# Altium schematic sheet Power.SchDoc
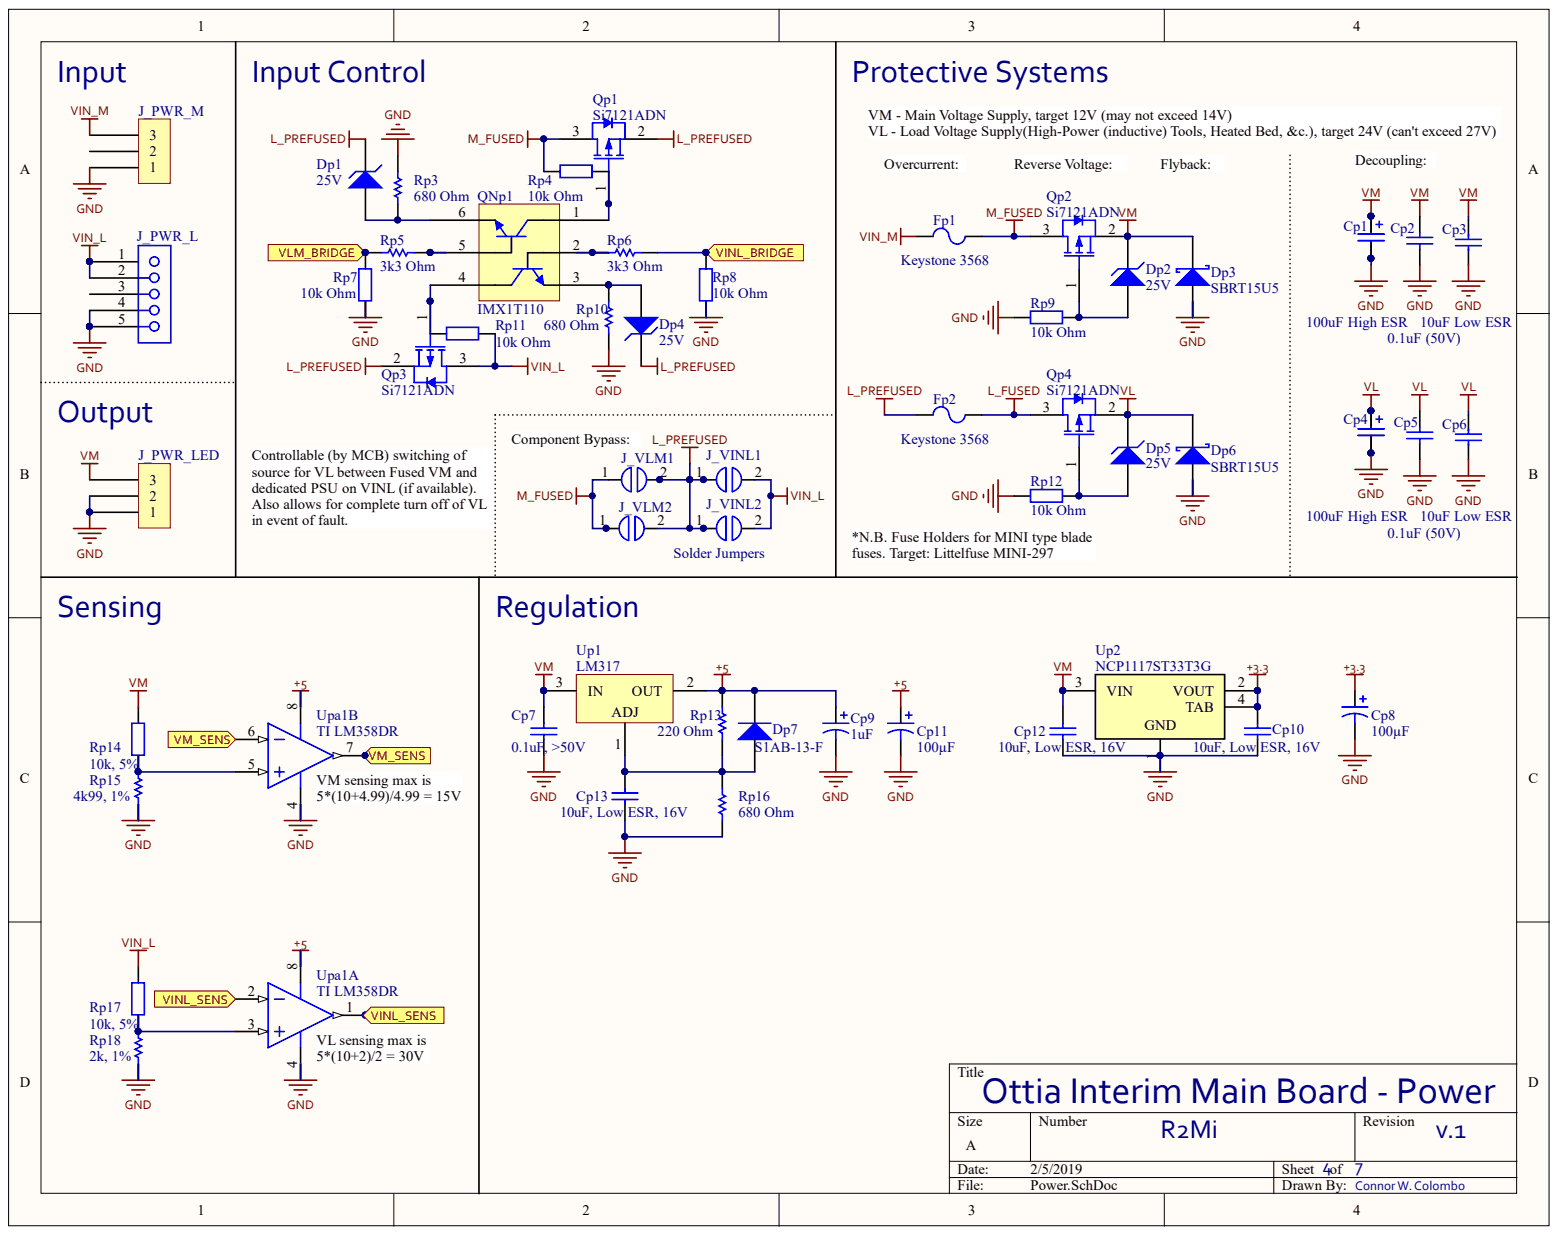
[diagram p0: Power.SchDoc]

## Parts
Cp1  100uF High ESR  [PCB-89xrrqtk3pzsh8e0jed3-1]
Cp2  0.1uF (50V)  [RLP133A]  (value not drawn)
Cp3  10uF Low ESR  [PCB-2281klue1gubltmsqu2t-1]
Cp4  100uF High ESR  [PCB-89xrrqtk3pzsh8e0jed3-1]
Cp5  0.1uF (50V)  [RLP133A]  (value not drawn)
Cp6  10uF Low ESR  [PCB-2281klue1gubltmsqu2t-1]
Cp7  0.1uF, >50V  [CAPC3225X230X50LL20T25]
Cp8  100µF  [PCB-8drnad0ct2lcpyfqi7nf-1]
Cp9  1uF  [PCB-rufcymyklsjsmb7phwvw-1]
Cp10  10uF, Low ESR, 16V  [CAPC3225X170X50NL20T25]
Cp11  100µF  [PCB-8drnad0ct2lcpyfqi7nf-1]
Cp12  10uF, Low ESR, 16V  [CAPC3225X170X50NL20T25]
Cp13  10uF, Low ESR, 16V  [CAPC3225X170X50NL20T25]
Dp1  25V  [PCB-twzvfyfoe7l7t2fej16y-1]
Dp2  25V  [PCB-twzvfyfoe7l7t2fej16y-1]
Dp3  SBRT15U5  [PCB-3b27tvws7ota4tfhp8k4-1]
Dp4  25V  [PCB-twzvfyfoe7l7t2fej16y-1]
Dp5  25V  [PCB-twzvfyfoe7l7t2fej16y-1]
Dp6  SBRT15U5  [PCB-3b27tvws7ota4tfhp8k4-1]
Dp7  S1AB-13-F  [DIOD-S1AB-13-F-2_V]
Fp1  Keystone 3568  [PCB-yfjavw4ffbkbh7q8at5e-1]
Fp2  Keystone 3568  [PCB-yfjavw4ffbkbh7q8at5e-1]
J_PWR_L  Phoenix Contact 1935190  [PCB-9cox3ryyxusip5ca5ajq-1]  (value not drawn)
J_PWR_LED  Phoenix Contact 1935174  [PCB-ze6c03jjo3jausbqidy3-1]  (value not drawn)
J_PWR_M  Phoenix Contact 1935174  [PCB-ze6c03jjo3jausbqidy3-1]  (value not drawn)
J_VINL1  Solder Jumper  [PCB-6gvn9cty3r54iuqtdtvh-1]
J_VINL2  Solder Jumpers  [PCB-6gvn9cty3r54iuqtdtvh-1]
J_VLM1  (no value)  [PCB-6gvn9cty3r54iuqtdtvh-1]
J_VLM2  Solder Jumper  [PCB-6gvn9cty3r54iuqtdtvh-1]
QNp1  IMX1T110  [PCB-ev6a9z41c1aidqix7mtx-1]
Qp1  Si7121ADN  [PCB-9j89h1wp35ih7gu092bc-1]
Qp2  Si7121ADN  [PCB-9j89h1wp35ih7gu092bc-1]
Qp3  Si7121ADN  [PCB-9j89h1wp35ih7gu092bc-1]
Qp4  Si7121ADN  [PCB-9j89h1wp35ih7gu092bc-1]
Rp3  680 Ohm  [0603R_B]
Rp4  10k Ohm  [PCB-55aunbi2drca6iadvin9-1]
Rp5  3k3 Ohm  [R0603_A]
Rp6  3k3 Ohm  [R0603_A]
Rp7  10k Ohm  [PCB-55aunbi2drca6iadvin9-1]
Rp8  10k Ohm  [PCB-55aunbi2drca6iadvin9-1]
Rp9  10k Ohm  [PCB-55aunbi2drca6iadvin9-1]
Rp10  680 Ohm  [0603R_B]
Rp11  10k Ohm  [PCB-55aunbi2drca6iadvin9-1]
Rp12  10k Ohm  [PCB-55aunbi2drca6iadvin9-1]
Rp13  220 Ohm  [RESC1608X55X25LL10T15]
Rp14  10k, 5%  [PCB-55aunbi2drca6iadvin9-1]
Rp15  4k99, 1%  [PCB-bodjj4y65hwve89rlk5a-1]
Rp16  680 Ohm  [0603R_B]
Rp17  10k, 5%  [PCB-55aunbi2drca6iadvin9-1]
Rp18  2k, 1%  [RESC2013X70X40NL20T20]
Up1  LM317  [PCB-vzpx1rcaa7a70p69xlqt-1]
Up2  NCP1117ST33T3G  [SOT223_A]
Upa1  TI LM358DR  [SOIC8-1.27-5X4MM]  (parts A,B)

## Ports
VINL_BRIDGE, VINL_SENS, VLM_BRIDGE, VM_SENS

## Nets
+3.3: Cp8.1 Cp10.2 Up2.2(VOUT) Up2.4(TAB)
+5: Cp9.1 Cp11.1 Dp7.1 Rp13.2 Up1.2 Upa1.8(+5)
GND: Cp1.2 Cp2.1 Cp3.1 Cp4.2 Cp5.1 Cp6.1 Cp7.1 Cp8.2 Cp9.2 Cp10.1 Cp11.2 Cp12.1 Cp13.1 Dp3.1 Dp6.1 J_PWR_L.4 J_PWR_L.5 J_PWR_LED.1 J_PWR_LED.2 J_PWR_M.1 Rp3.2 Rp7.2 Rp8.2 Rp9.2 Rp10.1 Rp12.2 Rp15.1 Rp16.1 Rp18.1 Up2.1 Upa1.4
L_FUSED: Fp2.2 Qp4.3
L_PREFUSED: Dp1.2 Dp4.2 Fp2.1 J_VINL1.1 J_VINL2.1 J_VLM1.2 J_VLM2.2 Qp1.2 Qp3.2
M_FUSED: Fp1.2 J_VLM1.1 J_VLM2.1 Qp1.3 Qp2.3 Rp4.1
VIN_L: J_PWR_L.1 J_PWR_L.2 J_VINL1.2 J_VINL2.2 Qp3.3 Rp11.2 Rp17.2
VIN_M: Fp1.1 J_PWR_M.3
VL: Cp4.1 Cp5.2 Cp6.2 Dp5.2 Dp6.2 Qp4.2
VM: Cp1.1 Cp2.2 Cp3.2 Cp7.2 Cp12.2 Dp2.2 Dp3.2 J_PWR_LED.3 Qp2.2 Rp14.2 Up1.3 Up2.3(VIN)
VINL_BRIDGE: Rp6.2 Rp8.1 ; port VINL_BRIDGE
VINL_SENS: Upa1.1 Upa1.2 ; port VINL_SENS
VLM_BRIDGE: Rp5.1 Rp7.1 ; port VLM_BRIDGE
VM_SENS: Upa1.6 Upa1.7 ; port VM_SENS
NetCp13_2: Cp13.2 Dp7.2 Rp13.1 Rp16.2 Up1.1
NetDp1_1: Dp1.1 QNp1.6 Rp3.1
NetDp2_1: Dp2.1 Qp2.1 Rp9.1
NetDp4_1: Dp4.1 QNp1.3 Rp10.2
NetDp5_1: Dp5.1 Qp4.1 Rp12.1
NetQNp1_1: QNp1.1 Qp1.1 Rp4.2
NetQNp1_2: QNp1.2 Rp6.1
NetQNp1_4: QNp1.4 Qp3.1 Rp11.1
NetQNp1_5: QNp1.5 Rp5.2
NetRp14_1: Rp14.1 Rp15.2 Upa1.5
NetRp17_1: Rp17.1 Rp18.2 Upa1.3

Not connected: J_PWR_L 3; J_PWR_M 2

## Library data of the parts
Cp1 Cp4 = CMP-6b01a09dfdf1b4c6-1: Electrolytic capacitor SMD 100 µF 50 V 20 % (Ø) 10 mm Panasonic EEE-HBH101UAP 1 pc(s); Capacitance: 100 F; Capacitance Tolerance: ±20%; Voltage Rating (DC): 50.0 V; Component Kind: Standard; Library Name: FavoritesLibrary; Lifecycle Status: Not Listed by Manufacturer; Manufacturer Part Number: EEE-HBH101UAP; OctopartID: 6b01a09dfdf1b4c6; Octopart URL: http://octopart.com/eee-hbh101uap-panasonic-43430806; Packaging: Cut Tape (CT), Tape & Reel (TR); RoHS: Compliant; Size-Diameter: 10.0 mm; Size-Height: 10.2 mm
Cp2 Cp5 = 08055C104KAT2A: Generic Multilayer Ceramic Capacitor SMD 0805 (2012); Capacitance: 100 nF; Insulation Resistance: 100 GΩ; Lead-Free Status: Lead Free; Voltage Rating (DC): 50.0 V; Component Kind: Standard; Library Name: Octopart library; Mounting Style: Surface Mount; Number of Pins: 2; Packaging: Cut Tape (CT), Tape & Reel (TR); Size-Length: 2.01 mm
Cp3 Cp6 = GRM31CR61H106MA12L: Cap Ceramic 10uF 50V X5R 20% SMD 1206 85°C Embossed Plastic T/R; Capacitance: 10.0 µF; Case/Package (SI): 3216; Voltage Rating (DC): 50.0 V; Capacitance Tolerance: ±20%; Case/Package: 1206; Component Kind: Standard; Dielectric Characteristic: X5R; Library Name: Octopart library; Lifecycle Status: Active; Manufacturer Part Number: GRM31CR61H106MA12L; OctopartID: 39a2f2ac1075e665; Octopart URL: http://octopart.com/grm31cr61h106ma12l-murata-33819825; RoHS: Compliant; Size-Length: 3.20 mm; Size-Thickness: 1.60 mm; Size-Width: 1.60 mm
Cp7 = C1210V104KCRACTU: Multilayer Ceramic Capacitors MLCC - SMD/SMT 500volts 0.1uF 10% X7R; Capacitance: 1e-07 F; Lead-Free Status: R; Voltage Rating (DC): 500.0 V; Capacitance Tolerance: ±10%; Case/Package: 1210; Component Kind: Standard; Dielectric Characteristic: X7R; Dielectric Material: Ceramic; Library Name: Octopart library; Mounting Style: Surface Mount; OctopartID: 4e52860b66549195; Octopart Url: https://octopart.com/c1210v104kcractu-kemet-22220128; Packaging: Cut Tape (CT); REACH SVHC Compliance: No SVHC; RoHS: Compliant
Cp8 Cp11 = CMP-792eb0274520a6e9-4: 100uF 16 V Aluminium Electrolytic Capacitor, WX Series 2000h 6.3 (Dia.) x 5.5mm; Manufacturer Part Number: UWX1C101MCL1GB; Dielectric Material: Aluminum Electrolytic; Voltage Rating (DC): 16.0 V; Component Kind: Standard; Library Name: CommunityVault; Simulation: SIM-9089590kv9404u1gaanr-1
Cp9 = CMP-adcf64c4c6c97208-1: 1uF Solid MnO2 Tantalum Electrolytic Capacitor; 35 V dc +/-10%; T491 Series; Manufacturer Part Number: T491B105K035AT; Capacitance: 1.00 µF; Capacitance Tolerance: ±10%; Case/Package (SI): 3528-21; Equivalent Series Resistance (ESR): 5.00 ?; Lead-Free Status: Lead Free; Voltage Rating (DC): 35.0 V; Case/Package: 3528-21; Component Kind: Standard; Dielectric Material: Tantalum; Library Name: FavoritesLibrary; Mounting Style: Surface Mount; Number of Pins: 2; OctopartID: adcf64c4c6c97208; Octopart URL: https://octopart.com/t491b105k035at-kemet-85600; Operating Temperature: -55.0 °C to 125 °C; Packaging: Tape & Reel (TR), Cut Tape (CT); REACH SVHC Compliance: No SVHC; RoHS: Compliant; Size-Height: 1.90 mm; Size-Length: 3.50 mm; Size-Width: 2.80 mm
Cp10 Cp12 Cp13 = C1210C106Z4VACTU: Cap Ceramic 10uF 16V Y5V -20% to 80% SMD 1210 85C Plastic T/R; Capacitance: 1e-05 F; Lead-Free Status: Lead Free; Voltage Rating (DC): 16.0 V; Case/Package: 1210; Component Kind: Standard; Dielectric Characteristic: Y5V; Dielectric Material: Ceramic; Library Name: Octopart library; Manufacturer Part Number: C1210C106Z4VACTU; Mounting Style: Surface Mount; OctopartID: 5d189499fe5c82df; Octopart Url: https://octopart.com/c1210c106z4vac7800-kemet-9143842; RoHS: Compliant
Dp1 Dp2 Dp4 Dp5 = CMP-1a93d39dc437c554-1: BZT52C24 Series 500 mW 24 V 10 mA Surface Mount Zener Diode - SOD-123; Manufacturer Part Number: BZT52C24-7-F; Lead-Free Status: Lead Free; Output Current: 50.0 mA (max); Power Dissipation: 500 mW (max); Power Rating: 500 mW; Voltage Rating (DC): 24.0 V; Case/Package: SOD-123; Component Kind: Standard; Library Name: FavoritesLibrary; Lifecycle Status: Active; Mounting Style: Surface Mount; Number of Pins: 2; OctopartID: 1a93d39dc437c554; Octopart URL: https://octopart.com/bzt52c24-7-f-diodes+inc.-326594; Operating Temperature: -65.0 °C to 150 °C; Packaging: Tape, Roll; REACH SVHC Compliance: No SVHC; RoHS: Compliant
Dp3 Dp6 = SBRT15U50SP5-13: SBRT15U50SP5 Series 50 V 15 A Trench Super Barrier Rectifier - POWERDI-5; Lead-Free Status: Lead Free; Component Kind: Standard; Library Name: Octopart library; Lifecycle Status: Not Listed by Manufacturer; Manufacturer Part Number: SBRT15U50SP5-13; OctopartID: 634aa843fc7abc8d; Octopart URL: http://octopart.com/sbrt15u50sp5-13-diodes+inc.-33798742; RoHS: Compliant
Dp7 = S1AB-13-F: 1.0 A Surface Mount Glass Passivated Rectifier, SMB, -65 to 150 degC, Tape and Reel; Capacitance: 1e-11 F; Current Rating: 1.0 A; Lead-Free Status: Lead Free; Polarity: Standard; Voltage Rating (DC): 50.0 V; Component Kind: Standard; Library Name: Octopart library; Lifecycle Status: Not Listed by Manufacturer; Manufacturer Part Number: S1AB-13-F; Mounting Style: Surface Mount; OctopartID: ef0c2603dff730cb; Octopart Url: https://octopart.com/s1ab-13-f-diodes+inc.-333213; RoHS: Compliant
Fp1 Fp2 = CMP-a3526a2351f57c95-2: Auto Fuse Holder; Mini; THM; 1 Fuse; 30Amp; Hi-Temp Nylon; UL94V-0; Brass/Tin; Component Kind: Standard; Library Name: CommunityVault
J_PWR_L = CMP-e4972d9d199c3b50-3: Conn; Term Blk; PCB; Screw; 5; 5 mm; Front; 26-14 AWG; 17.5 A; 250 V; Solder; Lead-Free Status: Lead Free; Number of Positions: 5; Pin Pitch: 5.00 mm; Voltage Rating (DC): 320 V; Component Kind: Standard; Flammability Rating: UL 94 V-0; Housing Color: Green; Housing Material: Nylon; Insulation Material: Nylon; Library Name: CommunityVault; Manufacturer Part Number: 1935190; Mounting Style: Through Hole, Screw; Number of Rows: 1; OctopartID: e4972d9d199c3b50; Octopart URL: http://octopart.com/1935190-phoenix+contact-55405; RoHS: Compliant; Size-Height: 11.3 mm; Size-Length: 9.00 mm; Size-Width: 9.00 mm
J_PWR_LED J_PWR_M = CMP-751775-8: TERM BLOCK PCB 3POS 5.0MM GREEN; Lead-Free Status: Lead Free; Number of Positions: 3; Pin Pitch: 0.005 m; Voltage Rating (DC): 320.0 V; Component Kind: Standard; Flammability Rating: UL 94 V-0; Housing Color: Green; Housing Material: Nylon; Insulation Material: Nylon; Library Name: CommunityVault; Number of Rows: 1; OctopartID: 77abbea19aa7a86c; Octopart Url: https://octopart.com/1935174-phoenix+contact-51306; RoHS: Compliant; Size-Height: 0.0114 m; Size-Length: 0.015 m; Size-Width: 0.01 m
J_VINL1 J_VINL2 J_VLM1 J_VLM2 = CMP-6gvn9cty3r54iuqtdtvh-3: PCB Solder Jumper; Component Kind: Standard; CustomComponentID: 6gvn9cty3r54iuqtdtvh; Library Name: FavoritesLibrary; tYPE: Jumper
QNp1 = CMP-4d7a847536b192bd-1: ROHM IMX1T110 Dual NPN Bipolar Transistor; 0.15 A; 50 V; 6-Pin SMT; Current Rating: 150 mA; Lead-Free Status: Lead Free; Polarity: NPN, N-Channel; Power Dissipation: 300 mW (max); Voltage Rating (DC): 50.0 V; Case/Package: SOT-457, SOT-23; Component Kind: Standard; Library Name: FavoritesLibrary; Manufacturer Part Number: IMX1T110; Mounting Style: Surface Mount; Number of Pins: 6; OctopartID: 4d7a847536b192bd; Octopart URL: https://octopart.com/imx1t110-rohm-351917; Operating Temperature: -55.0 °C (min); Packaging: Cut Tape (CT); REACH SVHC Compliance: No SVHC; RoHS: Compliant
Qp1-Qp4 = CMP-93b258ad59ba4c75-1: P Channel 30 V 15 mO 3.5 W TrenchFET Power Mosfet - PowerPak 1212-8 (3x3); Manufacturer Part Number: SI7121ADN-T1-GE3; Lead-Free Status: Lead Free; Polarity: P-Channel; Component Kind: Standard; Library Name: FavoritesLibrary; Mounting Style: Surface Mount; OctopartID: 93b258ad59ba4c75; Octopart URL: https://octopart.com/si7121adn-t1-ge3-vishay-34991982; Package: PowerPAK 1212-8; Packaging: Tape & Reel (TR); RoHS: Compliant
Rp3 Rp10 Rp16 = ERJ-3EKF6800V: Precision resistor, SMD [Panasonic Automotive & Industrial Systems] ERJ3EKF6800V Precision resistor, SMD; Lead-Free Status: Lead Free; Power Rating: 100 mW; Resistance: 680 Ω; Resistance Tolerance: ±1%; Voltage Rating (DC): 75.0 V; Case/Package: 0603; Component Kind: Standard; Library Name: Octopart library; Lifecycle Status: Not Listed by Manufacturer; Manufacturer Part Number: ERJ-3EKF6800V; Mounting Style: Surface Mount; Number of Pins: 2; OctopartID: f0623a25b1972fa5; Octopart Url: http://octopart.com/erj-3ekf6800v-panasonic-55403667; Packaging: Tape & Reel (TR), Cut Tape (CT); REACH SVHC Compliance: No SVHC; RoHS: Compliant; Seller Landing  Url: https://octopart-clicks.com/click/track?country=US&ak=8157f166&sig=05f3d22&sid=1106&ppid=55403667&vpid=196780231&ct=offers
Rp4 Rp7-Rp9 Rp11 Rp12 Rp14 Rp17 = CMP-494d8056daa7968a-16: Res Thick Film 1.6 x 0.8 mm 10K Ohm 5% 0.1W(1/10W) 100ppm/ C Molded SMD Paper T/R; Component Kind: Standard; Library Name: CommunityVault
Rp5 Rp6 = RC0603JR-073K3L: Surface Mount Thick Film Resistor, RC Series, 3.3 kohm, 100 mW, - 5%, 50 V, 0603 [1608 Metric]; Case/Package (SI): 1608; Lead-Free Status: Lead Free; Power Rating: 100 mW; Resistance: 3.30 kΩ; Voltage Rating (DC): 50.0 V, 75.0 V; Component Kind: Standard; Library Name: Octopart library; Manufacturer Part Number: RC0603JR-073K3L; Octopart URL: https://octopart.com/rc0603jr-073k3l-yageo-40254922; Packaging: Tape & Reel; REACH SVHC Compliance: No SVHC; Size-Width: 800 µm
Rp13 = RC0603FR-07220RL: General Purpose Chip Resistors, 220 Ohm, +/- 1%, -55 to 155 degC, 0603 (1608 Metric), RoHS, Tape and Reel; Case/Package (SI): 1608; Lead-Free Status: Lead Free; Power Rating: 100 mW; Resistance: 220 Ω; Voltage Rating (DC): 50.0 V; Case/Package: 0603; Component Kind: Standard; DatasheetVersion: Rev. 4, 04/2009; Library Name: Octopart library; Manufacturer Part Number: RC0603FR-07220RL; Mounting Style: Surface Mount; Number of Pins: 2; OctopartID: 5d0f9417940482c8; Octopart Url: http://octopart.com/rc0603fr-07220rl-yageo-1240173; PackageDescription: 2-Pin Surface Mount Device, Body 1.6 x 0.8 mm; PackageReference: 0603; Packaging: Cut Tape (CT), Tape & Reel (TR); REACH SVHC Compliance: No SVHC; Resistance Tolerance: ±1%; RoHS: Compliant; Seller Landing  Url: https://octopart-clicks.com/click/track?country=US&ak=8157f166&sig=0216548&sid=5489&ppid=1240173&vpid=270386521&ct=offers; Size-Height: 450 µm; Size-Length: 1.60 mm; Size-Width: 800 µm
Rp15 = ERJ-3EKF4991V: RES SMD 4.99K OHM 1% 1/10W 0603; Component Kind: Standard; Library Name: Octopart library
Rp18 = ERJ-6ENF2001V: Thick Film Resistors - SMD 0805 2Kohms 1% Tol; Case/Package (SI): 2012; Lead-Free Status: Lead Free; Power Rating: 0.125 W; Resistance: 2000.0 Ω; Voltage Rating (DC): 150.0 V; Case/Package: 0805; Component Kind: Standard; Library Name: Octopart library; Lifecycle Status: Not Listed by Manufacturer; Manufacturer Part Number: ERJ-6ENF2001V; Mounting Style: Surface Mount; OctopartID: a9566311e5d47691; Octopart Url: https://octopart.com/erj-6enf2001v-panasonic-55405028; REACH SVHC Compliance: No SVHC; Resistance Tolerance: ±1%; RoHS: Compliant; Size-Height: 0.0006 m; Size-Length: 0.002 m; Size-Width: 0.00125 m
Up1 = LM317D2T-TR: Voltage regulator; linear, adjustable; 1.2÷37V; 1.5A; D2PAK; SMD; Input Voltage (DC): 40.0 V (max); Component Kind: Standard; Library Name: Octopart library
Up2 = CMP-19354120-7: IC REG LDO 3.3V 1A SOT223; Dropout Voltage: 1.2 V; Lead-Free Status: Lead Free; Number of Outputs: 1; Output Current: 1.0 A; Polarity: P-Channel; Case/Package: SOT-223; Component Kind: Standard; Halogen Free Status: Halogen Free; Library Name: CommunityVault; Lifecycle Status: Active; Manufacturer Part Number: NCP1117ST33T3G; Mounting Style: Surface Mount; Number of Pins: 3; OctopartID: d9f310f1ca900634; Octopart Url: https://octopart.com/ncp1117st33t3g-on+semiconductor-538117; Output Voltage: 3.3 V; REACH SVHC Compliance: No SVHC; RoHS: Compliant
Upa1 = LM358DR: Dual Operational Amplifiers 8-SOIC 0 to 70; Bandwidth: 700000.0 Hz; Input Offset Drift: 7e-06 V/K; Lead-Free Status: Lead Free; Number of Channels: 2; Slew Rate: 0.3 V/μs; Case/Package: SOIC; Component Kind: Standard; Gain Bandwidth Product: 700000.0 Hz; Library Name: Octopart library; Lifecycle Status: Active; Manufacturer Part Number: LM358DR; Mounting Style: Surface Mount; Number of Circuits: 2; Number of Pins: 8; OctopartID: da191315c37a05de; Octopart Url: http://octopart.com/lm358dr-texas+instruments-408581; REACH SVHC Compliance: No SVHC; RoHS: Compliant; Seller Landing  Url: https://octopart-clicks.com/click/track?country=US&ak=8157f166&sig=0c8e831&sid=2402&ppid=408581&vpid=121005569&ct=offers
